# Altium schematic sheet Main.SchDoc
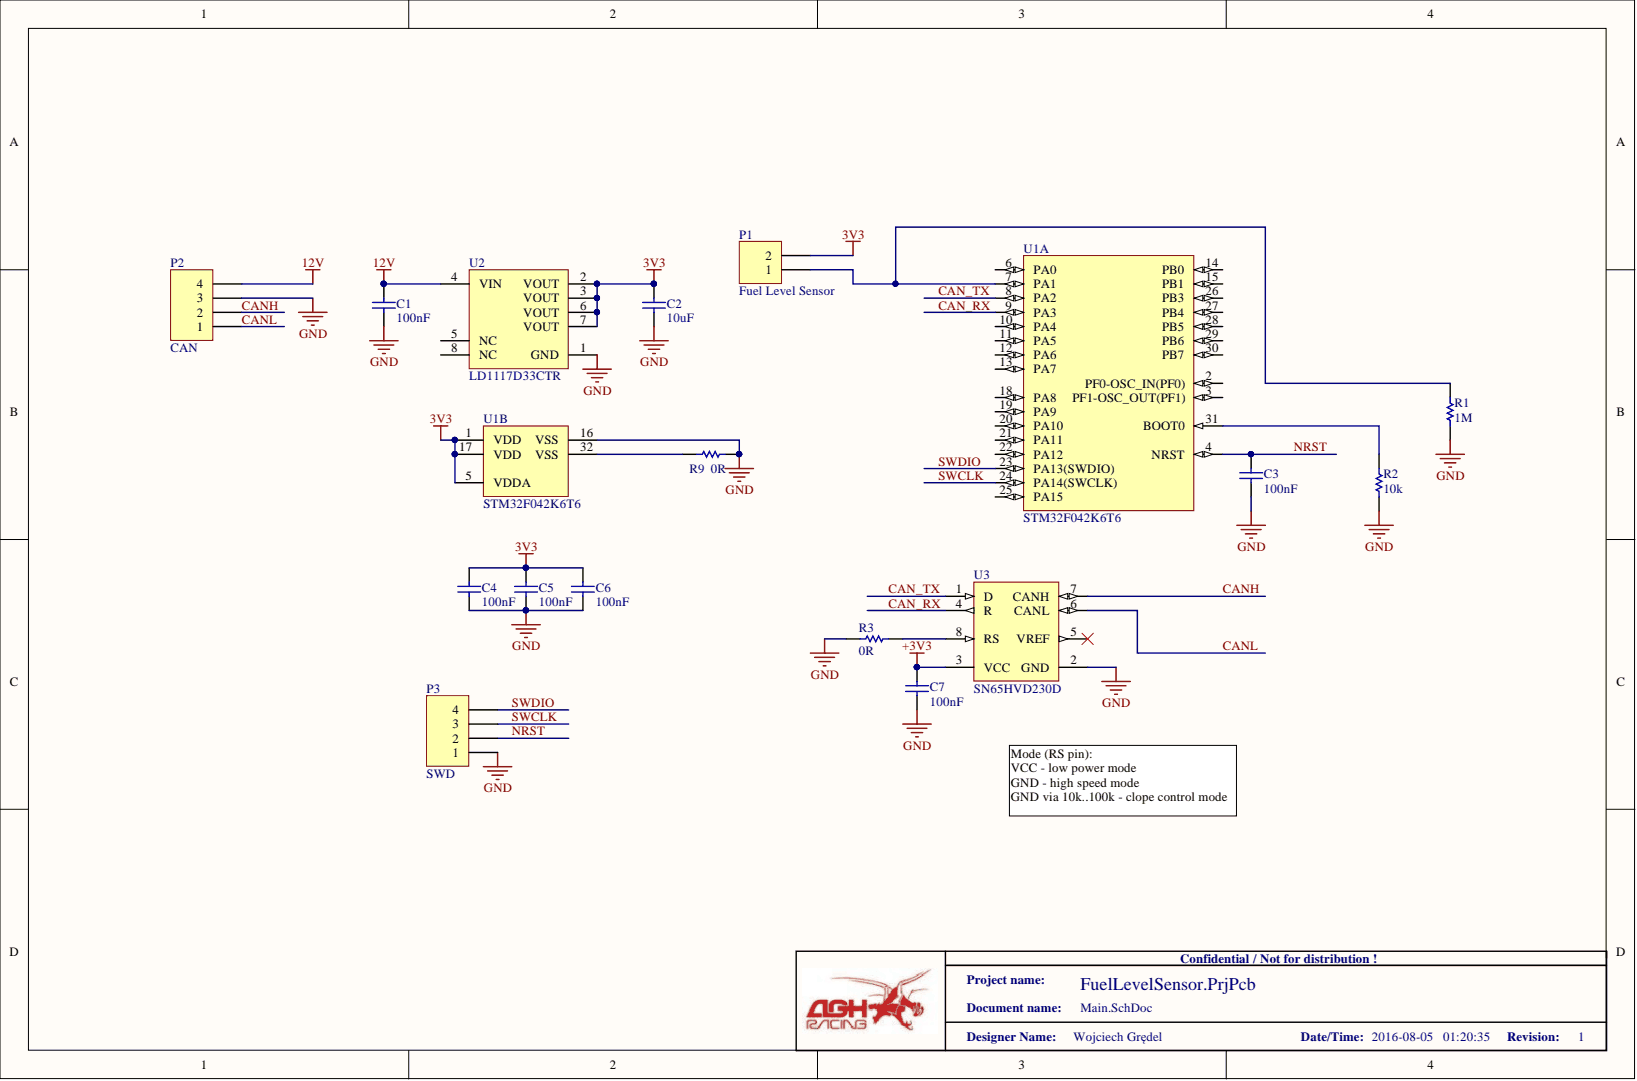
[diagram p0: Main.SchDoc]

## Parts
C1  value 100nF  [CAP_0805_IPC_MD]  (hidden comment: Cap)
C2  value 10uF  [CAP_0805_IPC_MD]  (hidden comment: Cap)
C3  value 100nF  [CAP_0805_IPC_MD]  (hidden comment: Cap)
C4  value 100nF  [CAP_0805_IPC_MD]  (hidden comment: Cap)
C5  value 100nF  [CAP_0805_IPC_MD]  (hidden comment: Cap)
C6  value 100nF  [CAP_0805_IPC_MD]  (hidden comment: Cap)
C7  value 100nF  [CAP_0805_IPC_MD]  (hidden comment: Cap)
P1  Fuel Level Sensor  [HDR1X2]
P2  CAN  [HDR1X4]
P3  SWD  [HDR1X4]
R1  value 1M  [RES_0805_IPC_MD]  (hidden comment: Res)
R2  value 10k  [RES_0805_IPC_MD]  (hidden comment: Res)
R3  value 0R  [RES_0805_IPC_MD]  (hidden comment: Res)
R9  value 0R  [RES_0805_IPC_MD]  (hidden comment: Res)
U1  STM32F042K6T6  [LQFP32_IPS_MD]  (parts A,B)
U2  LD1117D33CTR  [SOIC_8_IPC_MD]
U3  SN65HVD230D  [PCA82C250]

## Nets
3V3: C2.2 C4.2 C5.2 C6.2 P1.2 U1.1(VDD) U1.5(VDDA) U1.17(VDD) U2.2(VOUT) U2.3(VOUT) U2.6(VOUT) U2.7(VOUT)
12V: C1.2 P2.4 U2.4(VIN)
+3V3: C7.2 U3.3(VCC)
GND: C1.1 C2.1 C3.1 C4.1 C5.1 C6.1 C7.1 P2.3 P3.1 R1.1 R2.1 R3.1 R9.2 U1.16(VSS) U2.1(GND) U3.2(GND)
CAN_RX: U1.9(PA3) U3.4(R)
CAN_TX: U1.8(PA2) U3.1(D)
CANH: P2.2 U3.7(CANH)
CANL: P2.1 U3.6(CANL)
NRST: C3.2 P3.2 U1.4(NRST)
SWCLK: P3.3 U1.24(PA14(SWCLK))
SWDIO: P3.4 U1.23(PA13(SWDIO))
NetP1_1: P1.1 R1.2 U1.7(PA1)
NetR2_2: R2.2 U1.31(BOOT0)
NetR3_2: R3.2 U3.8(RS)
NetR9_1: R9.1 U1.32(VSS)

Not connected: U1 2(PF0-OSC_IN(PF0)) 3(PF1-OSC_OUT(PF1)) 6(PA0) 10(PA4) 11(PA5) 12(PA6) 13(PA7) 14(PB0) 15(PB1) 18(PA8) 19(PA9) 20(PA10) 21(PA11) 22(PA12) 25(PA15) 26(PB3) 27(PB4) 28(PB5) 29(PB6) 30(PB7); U2 5(NC) 8(NC); U3 5(VREF)

## Library data of the parts
C1-C6 = Cap: Capacitor; Component Kind: Standard; Signal Integrity: Cap; Simulation: CAP; Library Name: FuelLevelSensor.SchLib
C7 = Cap: Capacitor; Manufacturer: Samsung; Component Kind: Standard; Library Name: DataLogger.SchLib; Signal Integrity: Cap; Simulation: CAP; Supplier: TME; Supplier Part Number: CL21B104KBCNNNC
P1 = Header 2: Header, 2-Pin; Component Kind: Standard; Library Name: FuelLevelSensor.SchLib; Signal Integrity: Connector
P2 P3 = Header 4: Header, 4-Pin; Component Kind: Standard; Signal Integrity: Connector; Library Name: FuelLevelSensor.SchLib
R1-R3 R9 = Res: Resistor; Component Kind: Standard; Signal Integrity: Res; Simulation: RESISTOR
  R3 R9: Library Name: DataLogger.SchLib
  R1 R2: Library Name: FuelLevelSensor.SchLib
U1 = STM32F042K6T6: ARM Cortex-M0 32-bit MCU, 32 KB Flash, 6 KB Internal RAM, 26 I/Os, 32-pin LQFP, -40 to 85 degC, Tray; FLASH Size (Prog) (kB): 32; I/Os (High Current): 26; Internal RAM Size (kB): 4; Operating Frequency(F) (Processor speed) (MHz): 48; Supply Voltage(Vcc) min (V): 2.4; Component Kind: Standard; ComponentLink1Description: Manufacturer URL; ComponentLink1URL: http://www.st.com/web/en/home.html; ComponentLink2Description: Datasheet; ComponentLink2URL: http://www.st.com/st-web-ui/static/active/en/resource/technical/document/datasheet/DM00088500.pdf; ComponentLink3Description: Package Specification; ComponentLink3URL: http://www.st.com/st-web-ui/static/active/en/resource/technical/document/datasheet/DM00088500.pdf; Core: ARM Cortex-M0; DatasheetVersion: Rev. 1; Mounting Technology: Surface Mount; PackageDescription: 32-Pin Low Profile Quad Flat Package, 7 x 7 mm Body, 0.8 mm Pitch; PackageReference: LQFP32; PackageVersion: Rev. 1, 07/2013; Packing: Tray; PartNumber: STM32F042K6T6; Supplier 1: Digi-Key; Supplier 2: Newark; Supplier 3: Mouser; Supplier Part Number 1: 497-14046-ND; Supplier Part Number 2: 19X6194; Supplier Part Number 3: 511-STM32F030K6T6; Library Name: FuelLevelSensor.SchLib
U2 = LD1117D33CTR: Fixed Low Drop Positive Voltage Regulator, 3.3V, 8-Pin SO, Tape and Reel; Code_IPC: SOIC127P600-8; Code_JEDEC: MS-012-AA; Component Kind: Standard; ComponentLink1Description: Manufacturer URL; ComponentLink1URL: http://www.st.com; ComponentLink2Description: Datasheet; ComponentLink2URL: http://www.st.com/internet/com/TECHNICAL_RESOURCES/TECHNICAL_LITERATURE/DATASHEET/CD00000544.pdf; ComponentLink3Description: Package Specification; ComponentLink3URL: http://www.st.com/internet/com/TECHNICAL_RESOURCES/TECHNICAL_LITERATURE/PACKAGE_INFORMATION/CD00004680.pdf; DatasheetVersion: Nov-2011; Library Name: STMicroelectronics Power Management Linear Regulator.IntLib; PackageDescription: 8-Pin Small Outline Integrated Circuit 1.27 mm Pitch; PackageReference: SO8; PackageVersion: Dec-2002; PartNumber: LD1117D33CTR
U3 = SN65HVD230DR: 3.3V CAN Transceiver with Standby Mode, 17 mA, -40 to 85 degC, 8-pin SOIC (D), Green (RoHS & no Sb/Br); Manufacturer: Texas Instruments; Drivers Per Package: 1; ICC(Max)(mA): 17; Rating: Catalog; Supply Voltage(s)(V): 3.3; Transient Pulse Protection(V): -25 to 25; Code_JEDEC: MS-012AA; Component Kind: Standard; ComponentLink1Description: Datasheet; ComponentLink1URL: http://www.ti.com/general/docs/lit/getliterature.tsp?genericPartNumber=SN65HVD230&fileType=pdf; DatasheetVersion: SLOS346K; Eco-Plan: Green (RoHS & no Sb/Br); Isolated: No; Library Name: DataLogger.SchLib; Low Power Mode: Standby; Mounting Technology: Surface Mount; PackageDescription: 8-Pin Plastic Small Outline Integrated Circuit, 4.9 x 3.9 mm, Pitch 1.27 mm Pitch; PackageReference: D8; PackageVersion: revM, Jun-2011; PartNumber: SN65HVD230DR; Receivers Per Package: 1; SubFamily: CAN; Supplier: TME; Supplier 1: Digi-Key; Supplier 2: Digi-Key; Supplier 3: Digi-Key; Supplier 4: Farnell; Supplier 5: Farnell; Supplier 6: Newark; Supplier 7: Mouser; Supplier Part Number: SN65HVD230D; Supplier Part Number 1: 296-11654-2-ND; Supplier Part Number 2: 296-11654-1-ND; Supplier Part Number 3: 296-11654-6-ND; Supplier Part Number 4: 8452148RL; Supplier Part Number 5: 8452148; Supplier Part Number 6: 75C7745; Supplier Part Number 7: 595-SN65HVD230DR
